# Altium schematic sheet Sensors.SchDoc
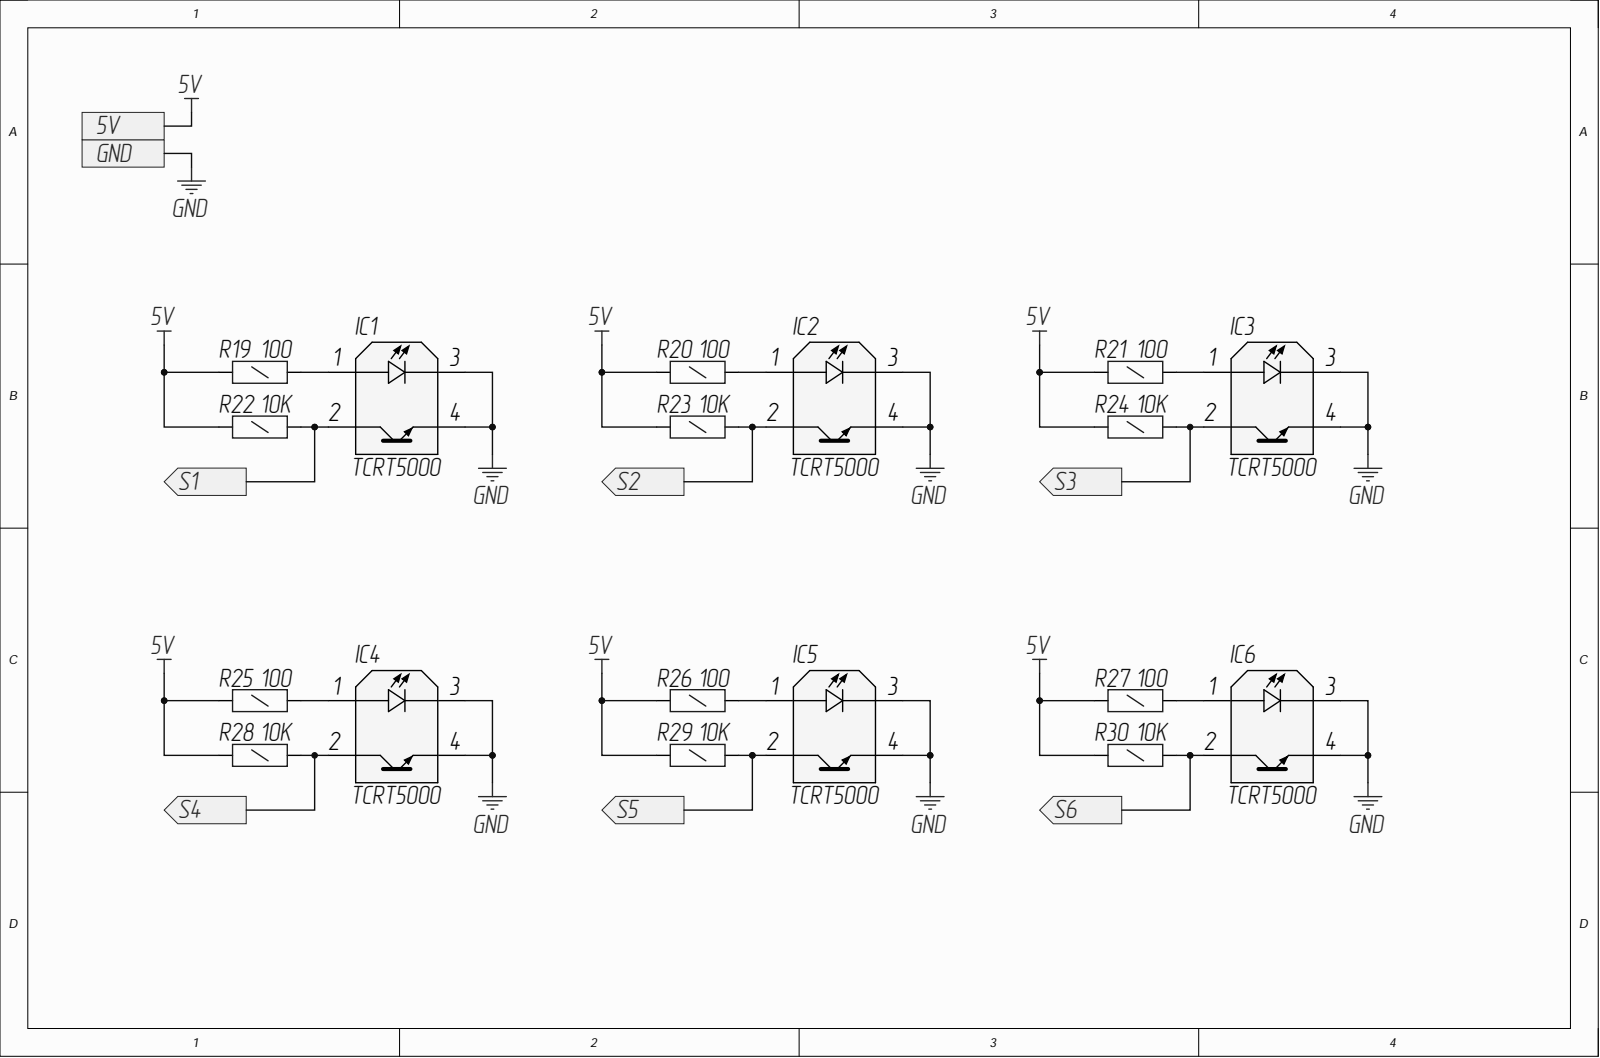
[diagram p0: Sensors.SchDoc]

## Parts
IC1  TCRT5000  [TCRT5000]
IC2  TCRT5000  [TCRT5000]
IC3  TCRT5000  [TCRT5000]
IC4  TCRT5000  [TCRT5000]
IC5  TCRT5000  [TCRT5000]
IC6  TCRT5000  [TCRT5000]
R19  100  [R0603]
R20  100  [R0603]
R21  100  [R0603]
R22  10K  [R0603]
R23  10K  [R0603]
R24  10K  [R0603]
R25  100  [R0603]
R26  100  [R0603]
R27  100  [R0603]
R28  10K  [R0603]
R29  10K  [R0603]
R30  10K  [R0603]

## Ports
5V, GND, S1, S2, S3, S4, S5, S6

## Nets
5V: R19.2 R20.2 R21.2 R22.2 R23.2 R24.2 R25.2 R26.2 R27.2 R28.2 R29.2 R30.2 ; port 5V
GND: IC1.3 IC1.4 IC2.3 IC2.4 IC3.3 IC3.4 IC4.3 IC4.4 IC5.3 IC5.4 IC6.3 IC6.4 ; port GND
S1: IC1.2 R22.1 ; port S1
S2: IC2.2 R23.1 ; port S2
S3: IC3.2 R24.1 ; port S3
S4: IC4.2 R28.1 ; port S4
S5: IC5.2 R29.1 ; port S5
S6: IC6.2 R30.1 ; port S6
NetIC1_1: IC1.1 R19.1
NetIC2_1: IC2.1 R20.1
NetIC3_1: IC3.1 R21.1
NetIC4_1: IC4.1 R25.1
NetIC5_1: IC5.1 R26.1
NetIC6_1: IC6.1 R27.1

## Library data of the parts
IC1-IC6 = TCRT5000: Инфракрасная оптопара; Component Kind: Standard; Library Name: LibSCH.SchLib
R19-R30 = Резистор  0,25Вт: Резистор 0,25Вт; Power rating: 0,25Вт; Component Kind: Standard; Library Name: LibSCH.SchLib
  R19-R21 R25-R27: Value: 100
  R22-R24 R28-R30: Value: 10K
